# Altium schematic sheet RS485_2.SchDoc
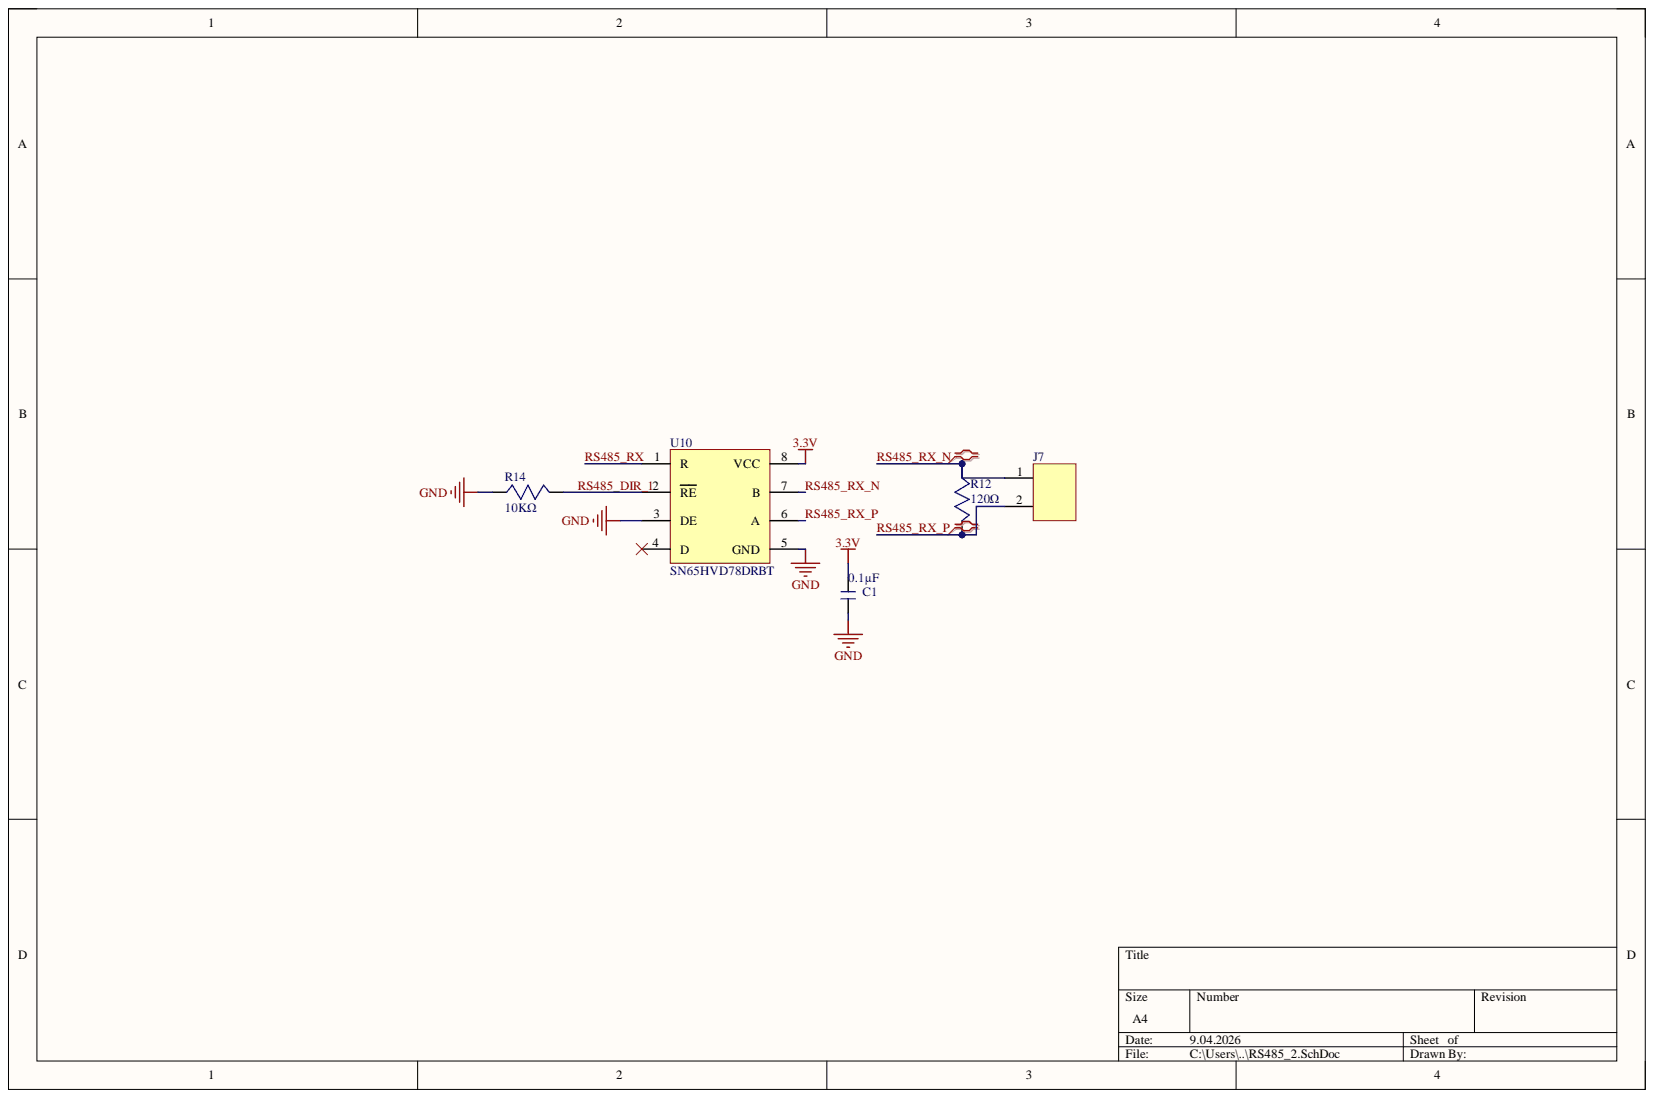
[diagram p0: RS485_2.SchDoc]

## Parts
C1  value 0.1µF  [402 capacitor - duplicate]  (hidden comment: 0402 capacitor)
J7  2 POS  [0901362102]  (value not drawn)
R12  value 120Ω  [402 RESISTOR]  (hidden comment: 0402. RESİSTOR)
R14  value 10KΩ  [402 RESISTOR]  (hidden comment: 0402. RESİSTOR)
U10  SN65HVD78DRBT

## Nets
3.3V: C1.2 U10.8(VCC)
GND: C1.1 R14.1 U10.3(DE) U10.5(GND)
RS485_DIR_1: R14.2 U10.2(RE)
RS485_RX: U10.1(R)
RS485_RX_N: J7.1 R12.1 U10.7(B)
RS485_RX_P: J7.2 R12.2 U10.6(A)

Not connected: U10 4(D)

## Library data of the parts
C1 = CON SMD 402: MPN: CL05A104KA5NNNC; Component Kind: Standard; Description: CON SMD 402; Library Name: Schlib1.SchLib; Package: 0402
J7 = 0901362102: CONN HEADER R/A 2POS 2.54MM; Component Kind: Standard; Library Name: Schlib1.SchLib; MPN: 0901362102
R12 = RES SMD 0402: MPN: ERJ-2RKF1200X; Component Kind: Standard; Description: RES SMD 0402; Library Name: Schlib1.SchLib; Package: 0402
R14 = RES SMD 0402: MPN: RNCF0402BTE10K0; Component Kind: Standard; Description: RES SMD 0402; Library Name: Schlib1.SchLib; Package: 0402
U10 = SN65HVD78DRBT: IC TRANSCEIVER HALF 1/1 8SON; Component Kind: Standard; Library Name: Schlib1.SchLib; MPN: SN65HVD78DRBT
